# Altium schematic sheet Sheet2.SchDoc(Sheet2)
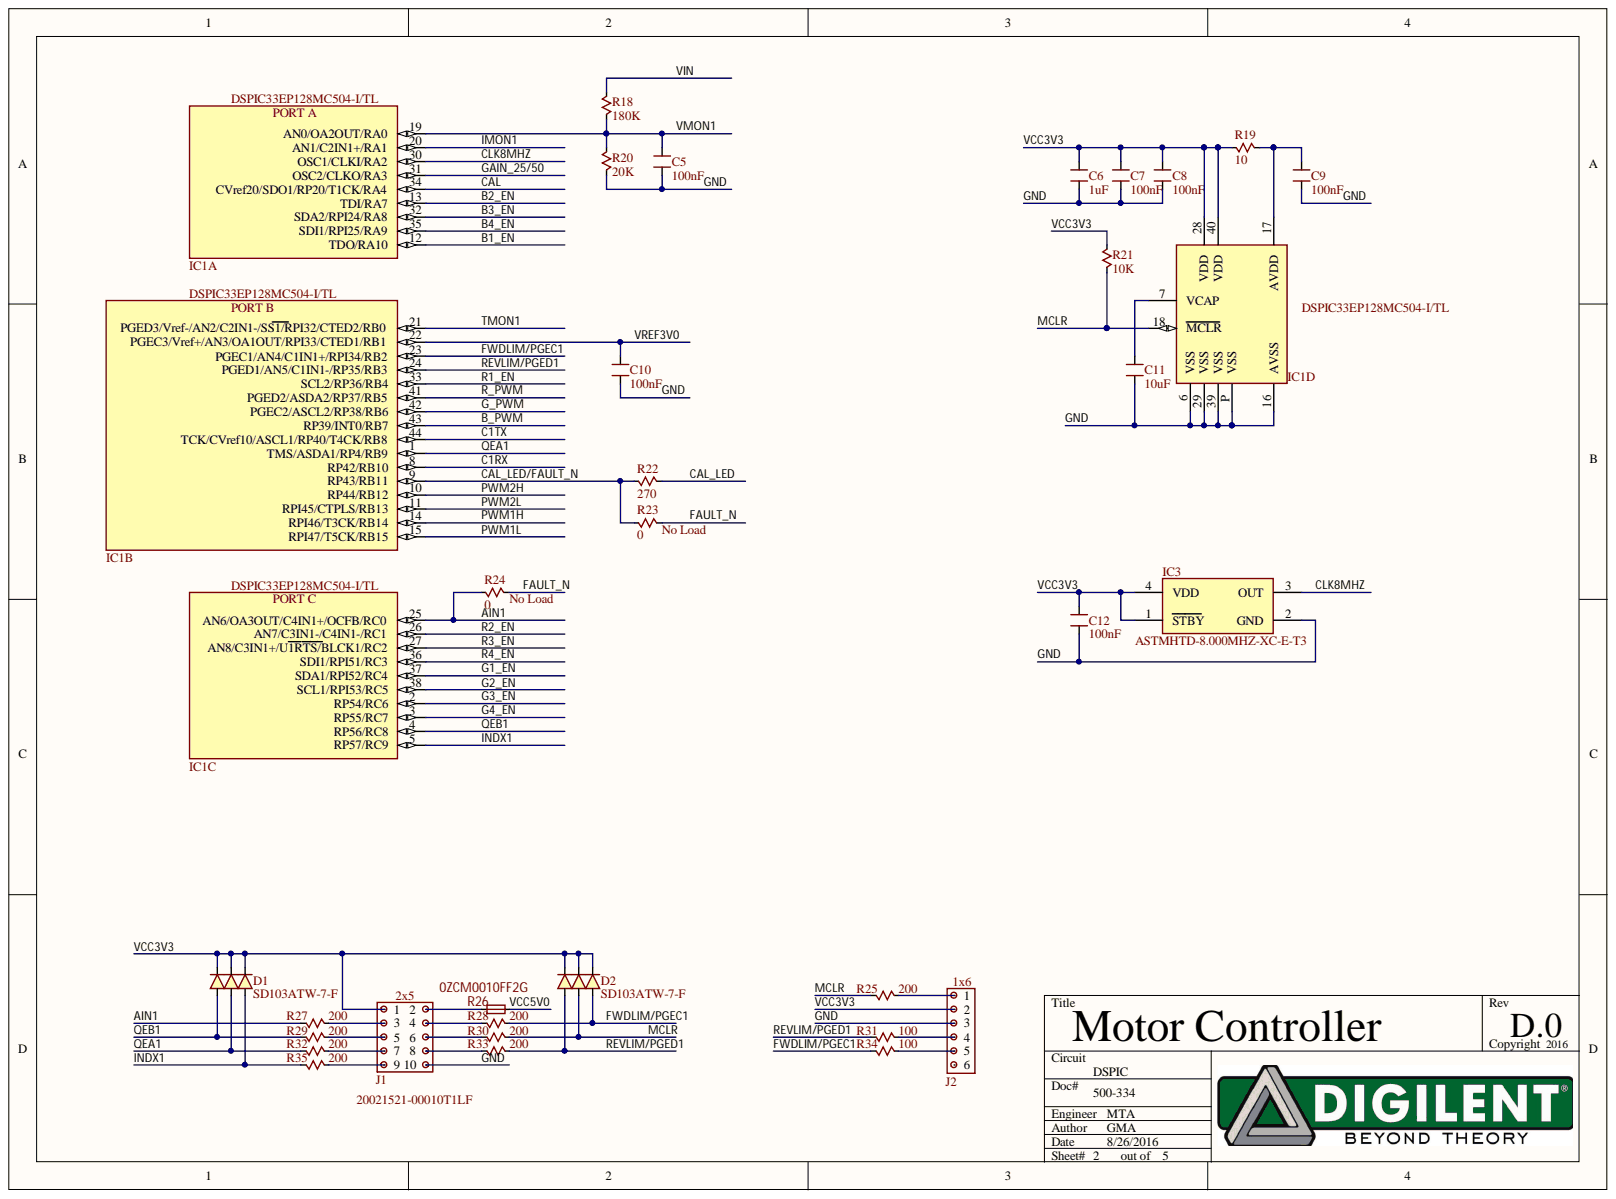
[diagram p0: Sheet2.SchDoc]

## Parts
C5  value 100nF  [CAP0402]  (hidden comment: CAPACITOR)
C6  value 1uF  [CAP0402]  (hidden comment: CAPACITOR)
C7  value 100nF  [CAP0402]  (hidden comment: CAPACITOR)
C8  value 100nF  [CAP0402]  (hidden comment: CAPACITOR)
C9  value 100nF  [CAP0402]  (hidden comment: CAPACITOR)
C10  value 100nF  [CAP0402]  (hidden comment: CAPACITOR)
C11  value 10uF  [CAP0603]  (hidden comment: CAPACITOR)
C12  value 100nF  [CAP0402]  (hidden comment: CAPACITOR)
D1  SD103ATW-7-F  [SOT-363]
D2  SD103ATW-7-F  [SOT-363]
IC1  DSPIC33EP128MC504-I/TL  [VTLA44]  (parts A,B,C,D)
IC3  ASTMHTD-8.000MHZ-XC-E-T3  [ASDMB]
J1  20021521-00010T1LF  [20021521-00010]
J2  HDR-1x6  [HDR-1x6-OFF]  (value not drawn)
R18  value 180K  [RES0402]  (hidden comment: RESISTOR)
R19  value 10  [RES0402]  (hidden comment: RESISTOR)
R20  value 20K  [RES0402]  (hidden comment: RESISTOR)
R21  value 10K  [RES0402]  (hidden comment: RESISTOR)
R22  value 270  [RES0402]  (hidden comment: RESISTOR)
R23  value 0  LoadStatus: No Load  [RES0402]  (hidden comment: RESISTOR)
R24  value 0  LoadStatus: No Load  [RES0402]  (hidden comment: RESISTOR)
R25  value 200  [RES0402]  (hidden comment: RESISTOR)
R26  0ZCM0010FF2G  [RES0603]
R27  value 200  [RES0402]  (hidden comment: RESISTOR)
R28  value 200  [RES0402]  (hidden comment: RESISTOR)
R29  value 200  [RES0402]  (hidden comment: RESISTOR)
R30  value 200  [RES0402]  (hidden comment: RESISTOR)
R31  value 100  [RES0402]  (hidden comment: RESISTOR)
R32  value 200  [RES0402]  (hidden comment: RESISTOR)
R33  value 200  [RES0402]  (hidden comment: RESISTOR)
R34  value 100  [RES0402]  (hidden comment: RESISTOR)
R35  value 200  [RES0402]  (hidden comment: RESISTOR)

## Nets
AIN1: IC1.25(AN6/OA3OUT/C4IN1+/OCFB/RC0) R24.1 R27.2
B1_EN: IC1.12(TDO/RA10)
B2_EN: IC1.13(TDI/RA7)
B3_EN: IC1.32(SDA2/RPI24/RA8)
B4_EN: IC1.35(SDI1/RPI25/RA9)
B_PWM: IC1.43(RP39/INT0/RB7)
C1RX: IC1.8(RP42/RB10)
C1TX: IC1.44(TCK/CVref10/ASCL1/RP40/T4CK/RB8)
CAL: IC1.34(CVref20/SDO1/RP20/T1CK/RA4)
CAL_LED: R22.2
CAL_LED/FAULT_N: IC1.9(RP43/RB11) R22.1 R23.1
CLK8MHZ: IC1.30(OSC1/CLKI/RA2) IC3.3(OUT)
FAULT_N: R23.2 R24.2
FWDLIM/PGEC1: D2.4 IC1.23(PGEC1/AN4/C1IN1+/RPI34/RB2) R28.1 R34.2
G1_EN: IC1.37(SDA1/RPI52/RC4)
G2_EN: IC1.38(SCL1/RPI53/RC5)
G3_EN: IC1.2(RP54/RC6)
G4_EN: IC1.3(RP55/RC7)
G_PWM: IC1.42(PGEC2/ASCL2/RP38/RB6)
GAIN_25/50: IC1.31(OSC2/CLKO/RA3)
GND: C5.2 C6.2 C7.2 C8.2 C9.2 C10.2 C11.2 C12.2 IC1.6(VSS) IC1.16(AVSS) IC1.29(VSS) IC1.39(VSS) IC1.P(VSS) IC3.2(GND) J1.10 J2.3 R20.2
IMON1: IC1.20(AN1/C2IN1+/RA1)
INDX1: D1.4 IC1.5(RP57/RC9) R35.2
MCLR: D2.5 IC1.18(MCLR) R21.2 R25.2 R30.1
PWM1H: IC1.14(RPI46/T3CK/RB14)
PWM1L: IC1.15(RPI47/T5CK/RB15)
PWM2H: IC1.10(RP44/RB12)
PWM2L: IC1.11(RPI45/CTPLS/RB13)
QEA1: D1.5 IC1.1(TMS/ASDA1/RP4/RB9) R32.2
QEB1: D1.6 IC1.4(RP56/RC8) R29.2
R1_EN: IC1.33(SCL2/RP36/RB4)
R2_EN: IC1.26(AN7/C3IN1-/C4IN1-/RC1)
R3_EN: IC1.27(AN8/C3IN1+/U1RTS/BLCK1/RC2)
R4_EN: IC1.36(SDI1/RPI51/RC3)
R_PWM: IC1.41(PGED2/ASDA2/RP37/RB5)
REVLIM/PGED1: D2.6 IC1.24(PGED1/AN5/C1IN1-/RP35/RB3) R31.2 R33.1
TMON1: IC1.21(PGED3/Vref-/AN2/C2IN1-/SS1/RPI32/CTED2/RB0)
VCC3V3: C6.1 C7.1 C8.1 C12.1 D1.1 D1.2 D1.3 D2.1 D2.2 D2.3 IC1.28(VDD) IC1.40(VDD) IC3.1(STBY) IC3.4(VDD) J1.1 J2.2 R19.1 R21.1
VCC5V0: R26.1
VIN: R18.1
VMON1: C5.1 IC1.19(AN0/OA2OUT/RA0) R18.2 R20.1
VREF3V0: C10.1 IC1.22(PGEC3/Vref+/AN3/OA1OUT/RPI33/CTED1/RB1)
NetC9_1: C9.1 IC1.17(AVDD) R19.2
NetC11_1: C11.1 IC1.7(VCAP)
NetJ1_2: J1.2 R26.2
NetJ1_3: J1.3 R27.1
NetJ1_4: J1.4 R28.2
NetJ1_5: J1.5 R29.1
NetJ1_6: J1.6 R30.2
NetJ1_7: J1.7 R32.1
NetJ1_8: J1.8 R33.2
NetJ1_9: J1.9 R35.1
NetJ2_1: J2.1 R25.1
NetJ2_4: J2.4 R31.1
NetJ2_5: J2.5 R34.1

Not connected: J2 6

## Library data of the parts
C5-C12 = CAPACITOR: LoadStatus: Load; Component Kind: Standard; Description: Capacitor; Library Name: DigilentUN.IntLib
D1 D2 = SD103ATW: Triple Surface Mount Schottky Barrier Diode Array; Manufacturer: Diodes Inc; LoadStatus: Load; Component Kind: Standard; Library Name: DigilentUN.IntLib
IC1 = DSPIC33EP128MC504-I/TL: 44-Pin, 16-Bit Microcontroller and Digital Signal Controller with High-Speed PWM, Op Amps and Advanced Analog; Manufacturer: Microchip; LoadStatus: Load; Component Kind: Standard; Library Name: DigilentUN.IntLib
IC3 = ASDMB: Ultra Miniature Pure Silicon Clock Oscillator; Manufacturer: Abracon; LoadStatus: Load; Component Kind: Standard; Library Name: DigilentUN.IntLib
J1 = HDR-2x5: Header 100mil 2x5; LoadStatus: Load; Component Kind: Standard; Library Name: DigilentUN.IntLib
J2 = HDR-1x6: Header 100mil 1x6; LoadStatus: No Load; Component Kind: Standard; Library Name: DigilentUN.IntLib
R18-R22 R25 R27-R35 = RESISTOR: LoadStatus: Load; Component Kind: Standard; Description: Resistor; Library Name: DigilentUN.IntLib
R26 = PRG18B: Surface Mount PTC 0ZCM; Manufacturer: Belfuse; LoadStatus: Load; Component Kind: Standard; Library Name: DigilentUN.IntLib
R23 R24 = RESISTOR: Component Kind: Standard; Description: Resistor; Library Name: DigilentUN.IntLib
